# Altium schematic sheet Power.SchDoc
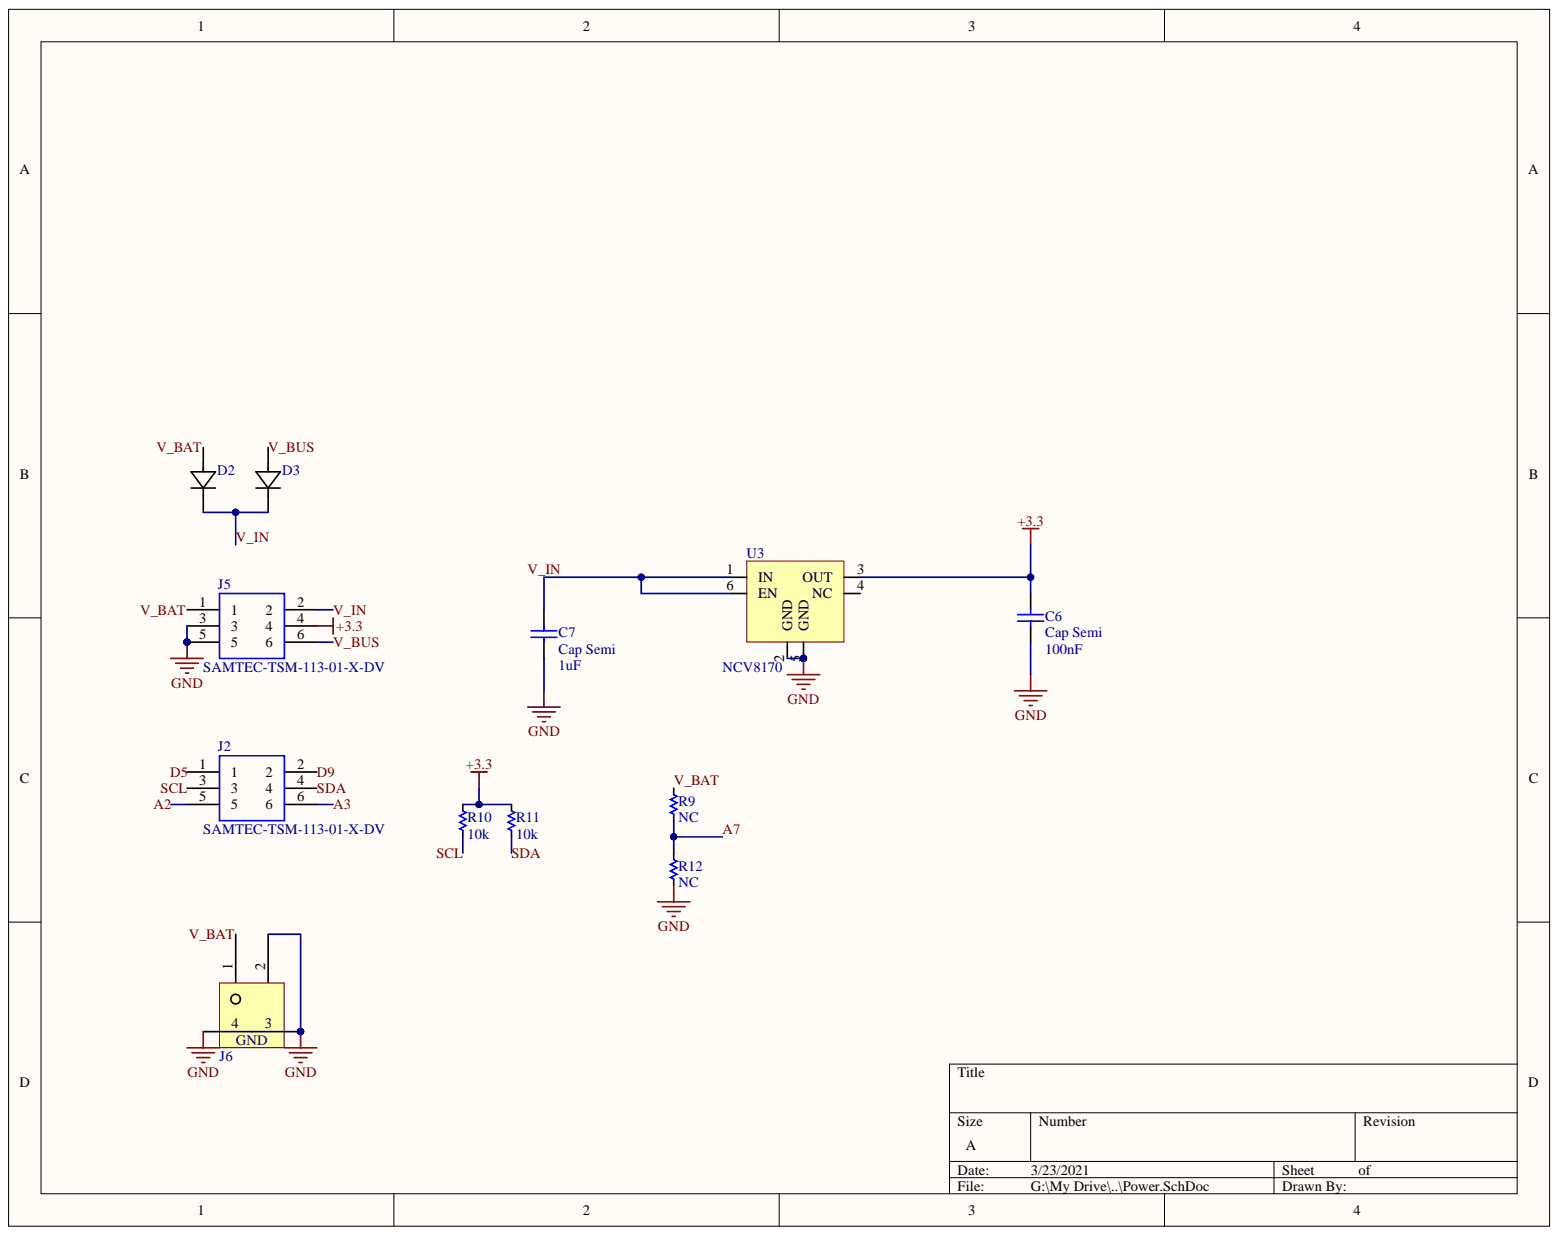
[diagram p0: Power.SchDoc]

## Parts
C6  Cap Semi  value 100nF  [1608[0603]]
C7  Cap Semi  value 1uF  [1608[0603]]
D2  Part Number: MBR120VLSF  [SOD-123FL]  (value not drawn)
D3  Part Number: MBR120VLSF  [SOD-123FL]  (value not drawn)
J2  SAMTEC-TSM-113-01-X-DV  [SAMTEC-TSM-113-01-X-DV]
J5  SAMTEC-TSM-113-01-X-DV  [SAMTEC-TSM-113-01-X-DV]
J6  (no value)  [PCBComponent_1]
R9  NC  [RES-SMD-0603]
R10  10k  [RES-SMD-0603]
R11  10k  [RES-SMD-0603]
R12  NC  [RES-SMD-0603]
U3  NCV8170  [SOT-563]

## Nets
+3.3: C6.2 J5.4 R10.1 R11.1 U3.3(OUT)
GND: C6.1 C7.1 J5.3 J5.5 J6.2 J6.3 J6.4 R12.2 U3.2(GND) U3.5(GND)
A2: J2.5
A3: J2.6
A7: R9.2 R12.1
D5: J2.1
D9: J2.2
SCL: J2.3 R10.2
SDA: J2.4 R11.2
V_BAT: D2.2 J5.1 J6.1 R9.1
V_BUS: D3.2 J5.6
V_IN: C7.2 D2.1 D3.1 J5.2 U3.1(IN) U3.6(EN)

Not connected: U3 4(NC)

## Library data of the parts
C6 C7 = Cap Semi: Capacitor (Semiconductor SIM Model); Component Kind: Standard; LatestRevisionDate: 29-May-2009; LatestRevisionNote: IPC-7351 Footprint Added.; Library Name: Miscellaneous Devices.IntLib; PackageDescription: Chip Capacitor; PackageReference: C1206; Published: 8-Jun-2000; Signal Integrity: Cap Semi; Simulation: CAP; Publisher: Altium Limited
D2 D3 = MBR120VLSF: Diode Schottky 20V 1A Surface Mount SOD-123FL; Manufacturer_Part_Number: SMF22A; Built_By: EMA_UL_Team; Type: DEV; Vendor: Littelfuse Inc; Component Kind: Standard; Library Name: MyLibrary-001.IntLib; RefDes: RefDes; Value: Value; Copyright: Copyright (C) 2018 Accelerated Designs. All rights reserved
J6 = J?: Component Kind: Standard; Library Name: PH_Connector_2Pin.IntLib
R9 R12 = Res-SMD: Resistor; Manufacturer: Generic Components; Class: Passive; Value: 10k; Component Kind: Standard; Library Name: LIBRARY.IntLib; Published: 08/06/2000; Publisher: Altium Hobart Technology Centre
R10 R11 = Res-SMD: Resistor; Manufacturer: Generic Components; Class: Passive; Component Kind: Standard; Library Name: LIBRARY.IntLib; Published: 08/06/2000; Value: 10k; Publisher: Altium Hobart Technology Centre
U3 = NCV8170: Linear Voltage Regulator IC Positive Fixed 1 Output  150mA SOT-563-6; Component Kind: Standard; Library Name: MyLibrary-001.IntLib
J2 J5 = SAMTEC-TSM-113-01-X-DV: Availability: Unavailable; Component Kind: Standard; Library Name: SAMTEC-TSM-113-01-X-DV.IntLib; MP: SAMTEC-TSM-113-01-X-DV; Package: None; Price: None
